# Altium schematic sheet Top.SchDoc(Top)
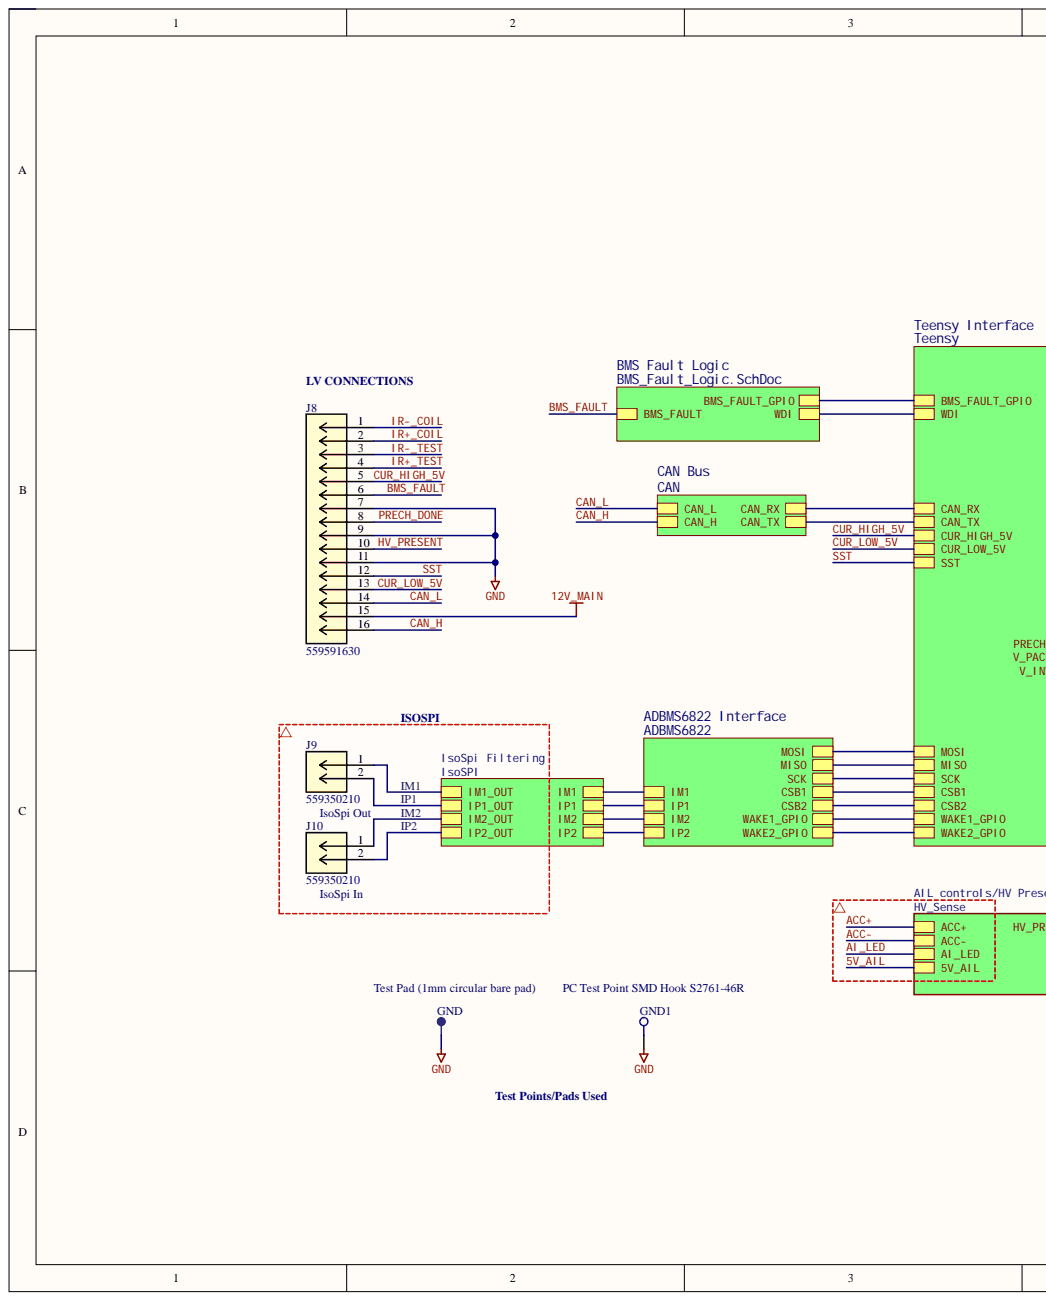
[diagram p0: Top.SchDoc, left]
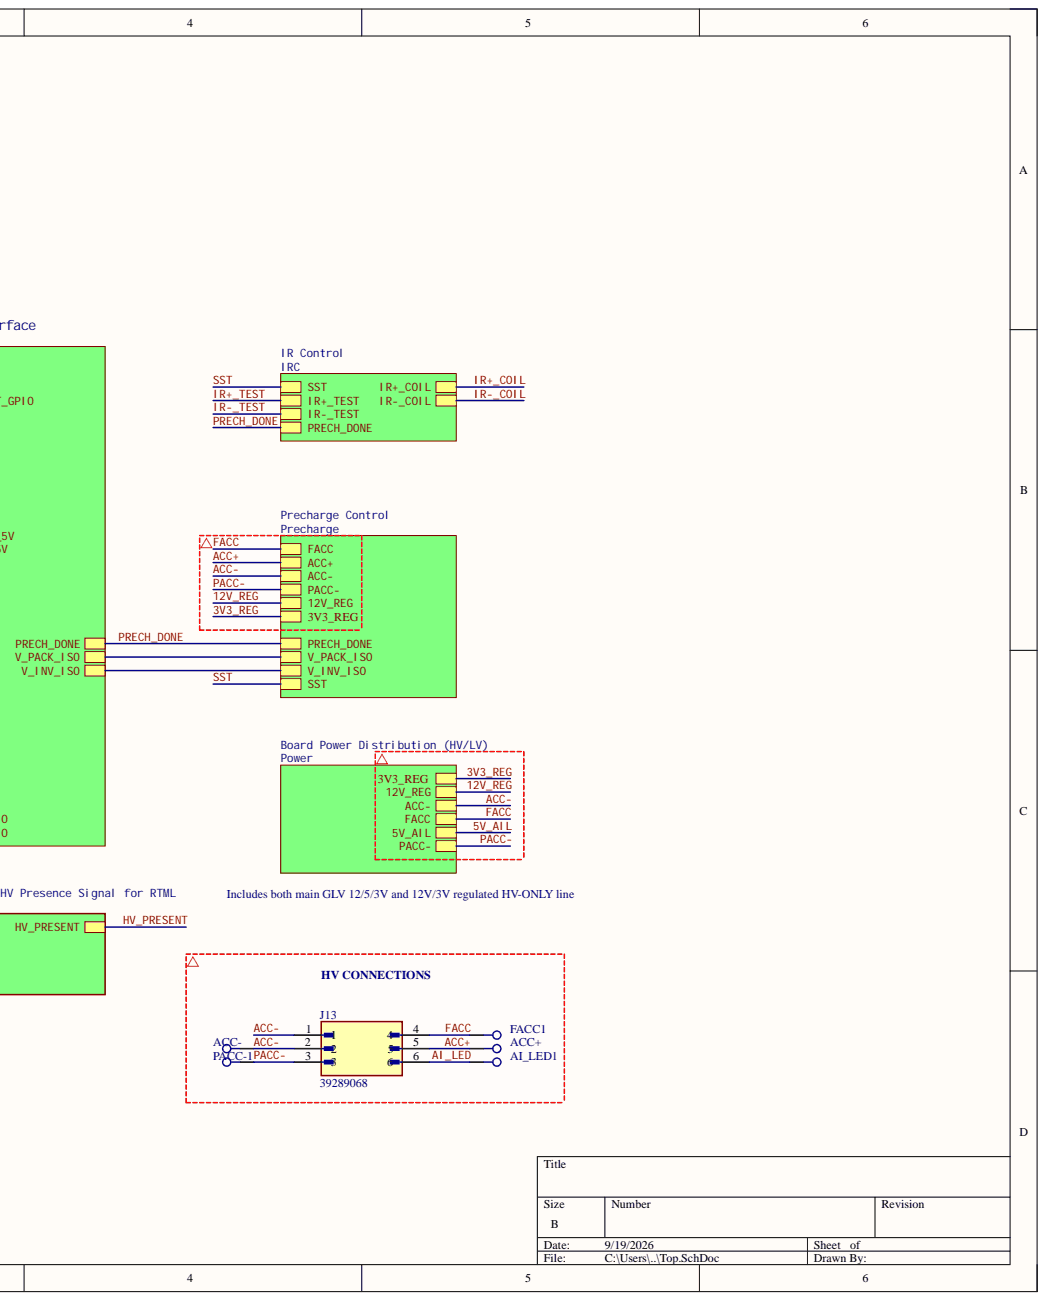
[diagram p1: Top.SchDoc, right]

## Parts
ACC+  S2761-46R  [FP-S2761-46R-MFG]
ACC-  S2761-46R  [FP-S2761-46R-MFG]
AI_LED1  S2761-46R  [FP-S2761-46R-MFG]
FACC1  S2761-46R  [FP-S2761-46R-MFG]
GND  TEST PAD  [Test_Pad]  (value not drawn)
GND1  S2761-46R  [FP-S2761-46R-MFG]
J8  559591630  [CONN16_559591630_MOL]
J9  559350210  [CONN02_559350210_MOL]
J10  559350210  [CONN02_559350210_MOL]
J13  39289068  [MOLEX_39289068]
PACC-1  S2761-46R  [FP-S2761-46R-MFG]

## Nets
12V_MAIN: J8.15
GND: GND.TP? GND1.1 J8.7 J8.9 J8.11
ACC+: ACC+.1 J13.5
ACC-: ACC-.1 J13.1 J13.2
AI_LED: AI_LED1.1 J13.6
BMS_FAULT: J8.6
CAN_H: J8.16
CAN_L: J8.14
CUR_HIGH_5V: J8.5
CUR_LOW_5V: J8.13
FACC: FACC1.1 J13.4
HV_PRESENT: J8.10
IM1: J9.1
IM2: J10.1
IP1: J9.2
IP2: J10.2
IR+_COIL: J8.2
IR+_TEST: J8.4
IR-_COIL: J8.1
IR-_TEST: J8.3
PACC-: J13.3 PACC-1.1
PRECH_DONE: J8.8
SST: J8.12
3V3_REG:
5V_AIL:
12V_REG:
BMS_FAULT_GPIO:
CAN_RX:
CAN_TX:
CSB1:
CSB2:
MISO:
MOSI:
SCK:
V_INV_ISO:
V_PACK_ISO:
WAKE1_GPIO:
WAKE2_GPIO:
WDI:

## Library data of the parts
ACC+ ACC- AI_LED1 FACC1 GND1 PACC-1 = CMP-00111-1: Test Terminal for Standard Test Probes, Micro Test Lead Clips and Hooks Brass T/R; Component Kind: Standard; MFG PN: S2761-46R; Pins: 1; Library Name: Cornell University
GND = CMP-00030-00021-1: natsu's test pad; Component Kind: Standard; MFG PN: n/a; Pins: 1; Library Name: Cornell University
J8 = CMP-002-00301-2: 2.00mm Pitch MicroClasp Wire-to-Board Header, Dual Row, Right Angle, 16 Circuits; Manufacturer_Name: Molex Connector Corporation; Component Kind: Standard; Datasheet: https://www.molex.com/en-us/products/part-detail-pdf/559591630?display=pdf; Design Item ID: 559591630; Manufacturer_Part_Number: 559591630; RefDes: RefDes; Source: 559591630.SchLib; Type: Type; Copyright: Copyright (C) 2025 Ultra Librarian. All rights reserved.; Library Name: Cornell University
J9 J10 = CMP-456-000000-2: No Description Available; Mfr_Name: Molex Connector Corporation; Component Kind: Standard; Design Item ID: 559350210; RefDes: RefDes; Source: 559350210.SchLib; Type: Type; Library Name: Cornell University
J13 = CMP-002-00278-2: Conn Wire to Board HDR 6Power POS 4.2mm Solder ST Top Entry Thru-Hole Tray; Availability: Unavailable; Case/Package: None; Component Kind: Standard; Component Type: Standard; Design Item ID: 39289068; MP: 39289068; Pins: 6; Price: None; Source: 39289068.SchLib; Library Name: Cornell University
